# Altium schematic sheet front-end.SchDoc
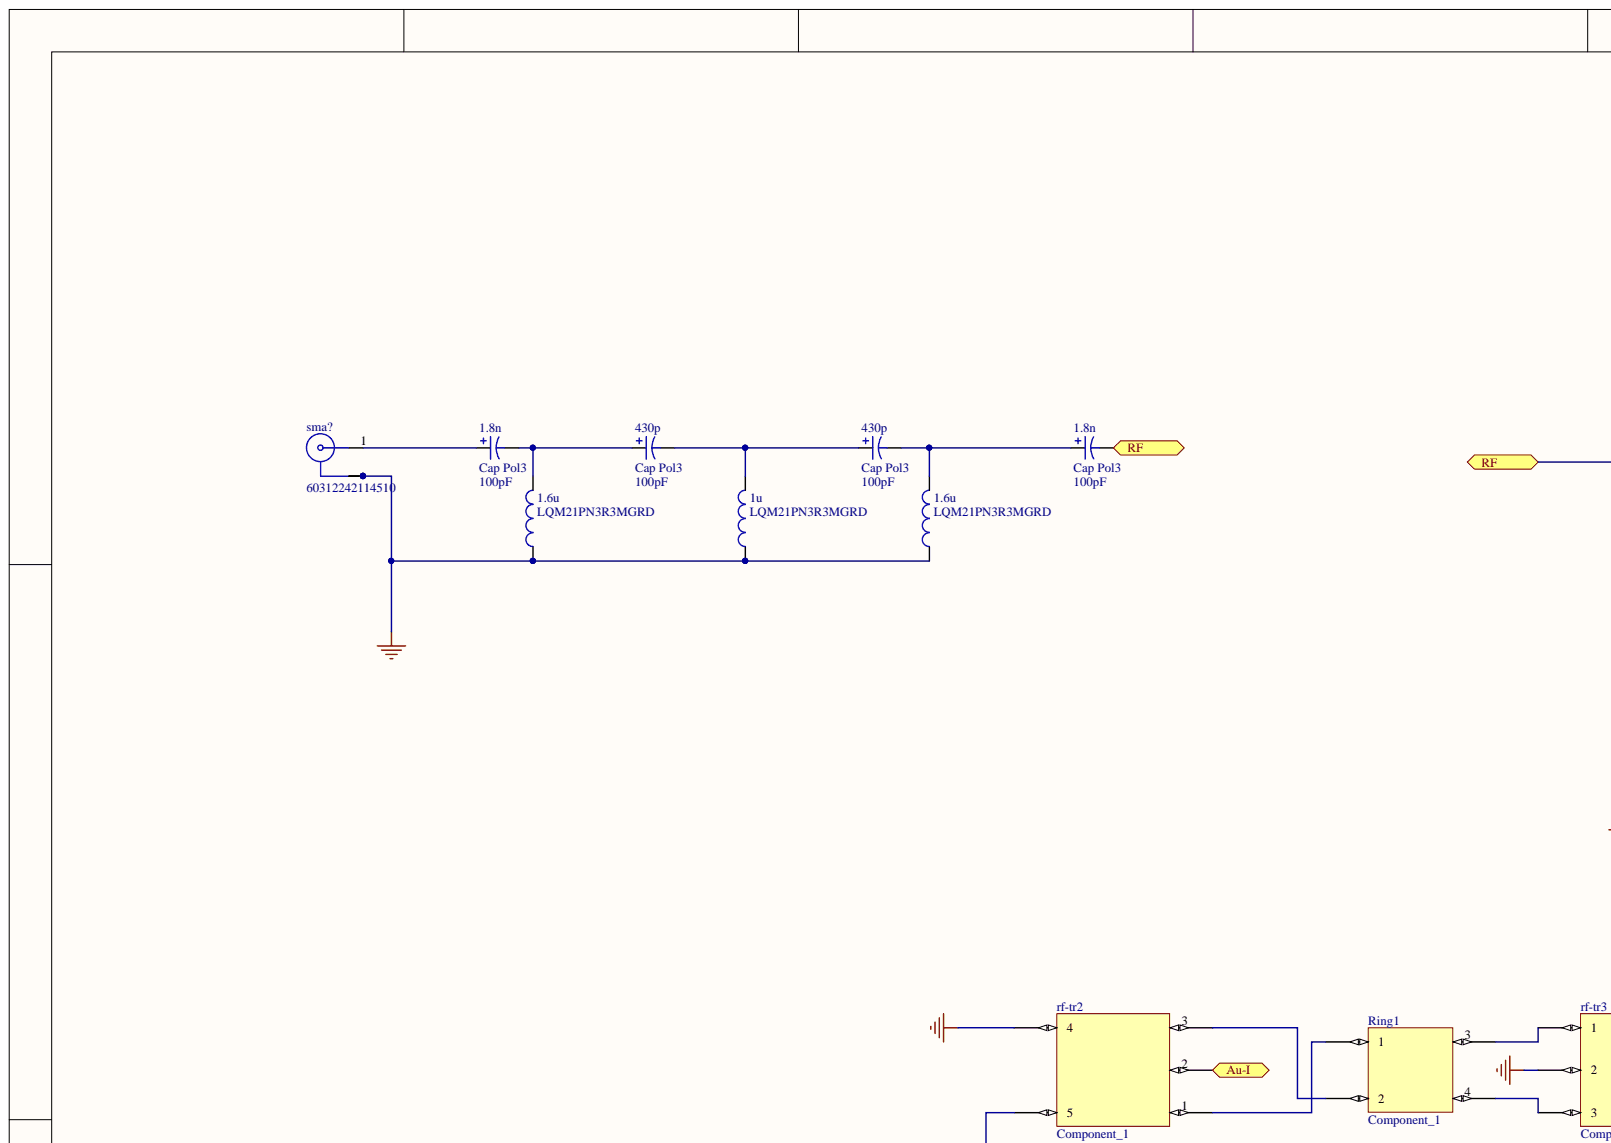
[diagram p0: front-end.SchDoc, top left]
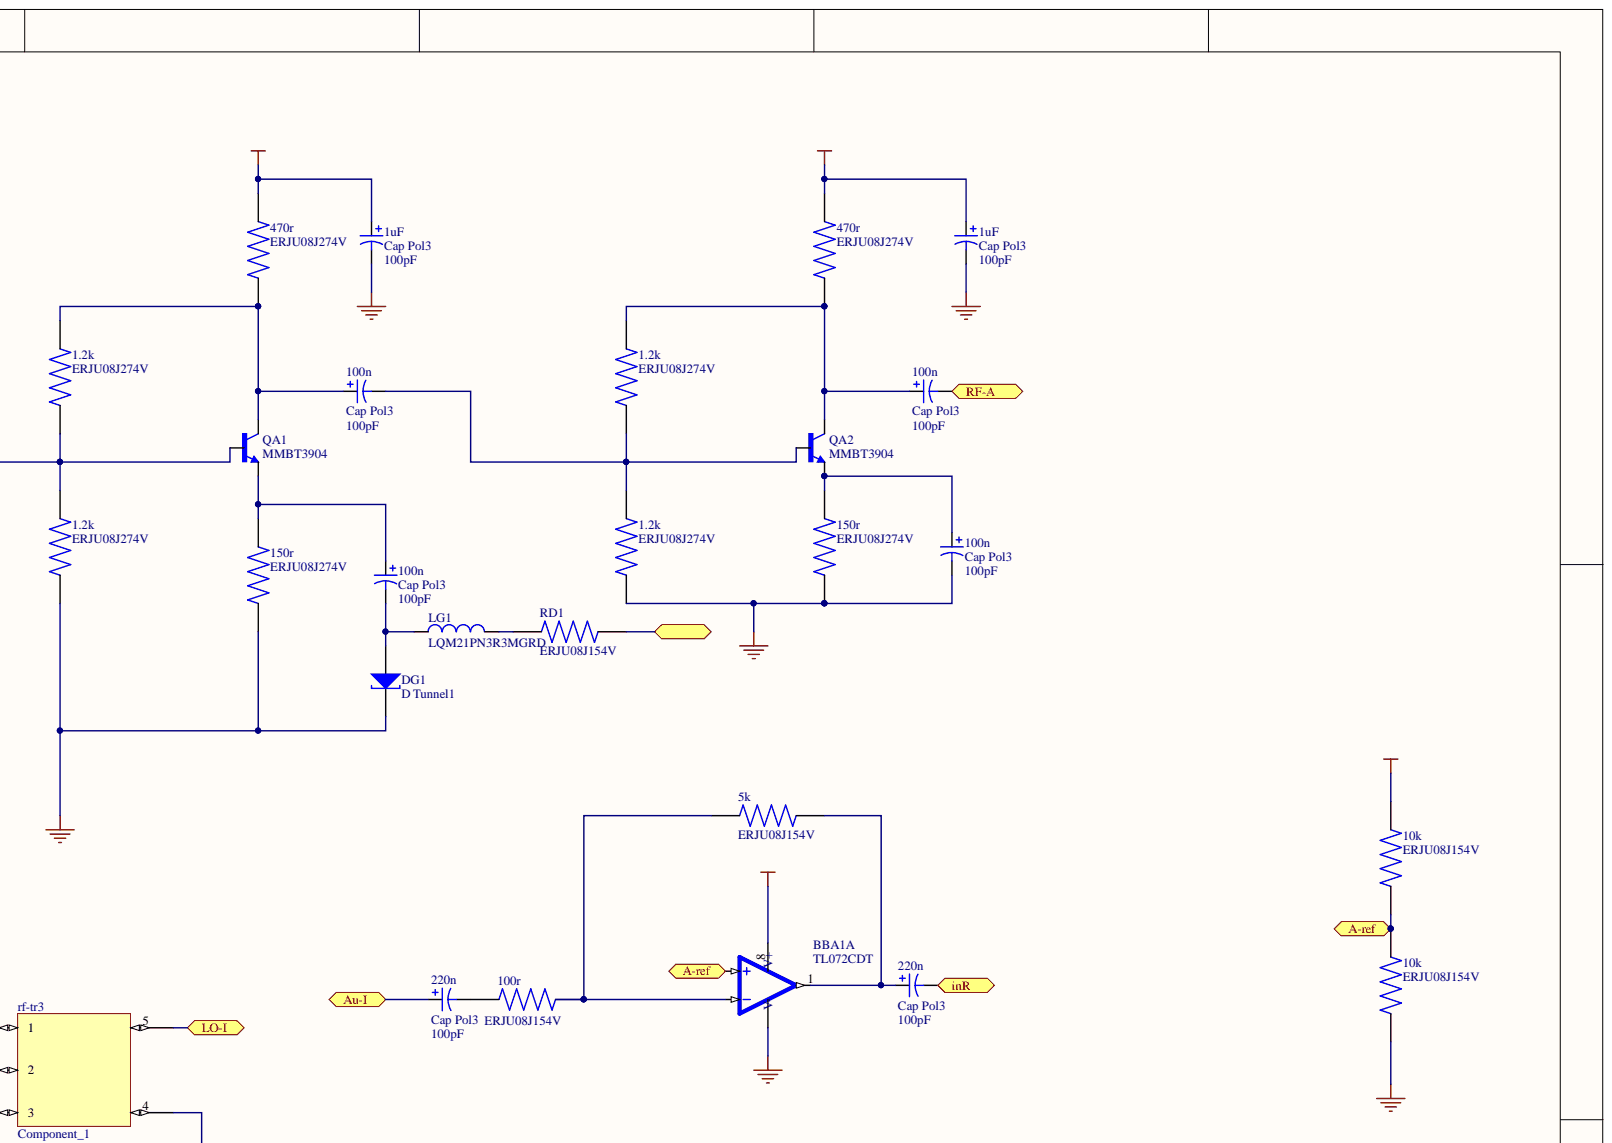
[diagram p1: front-end.SchDoc, top right]
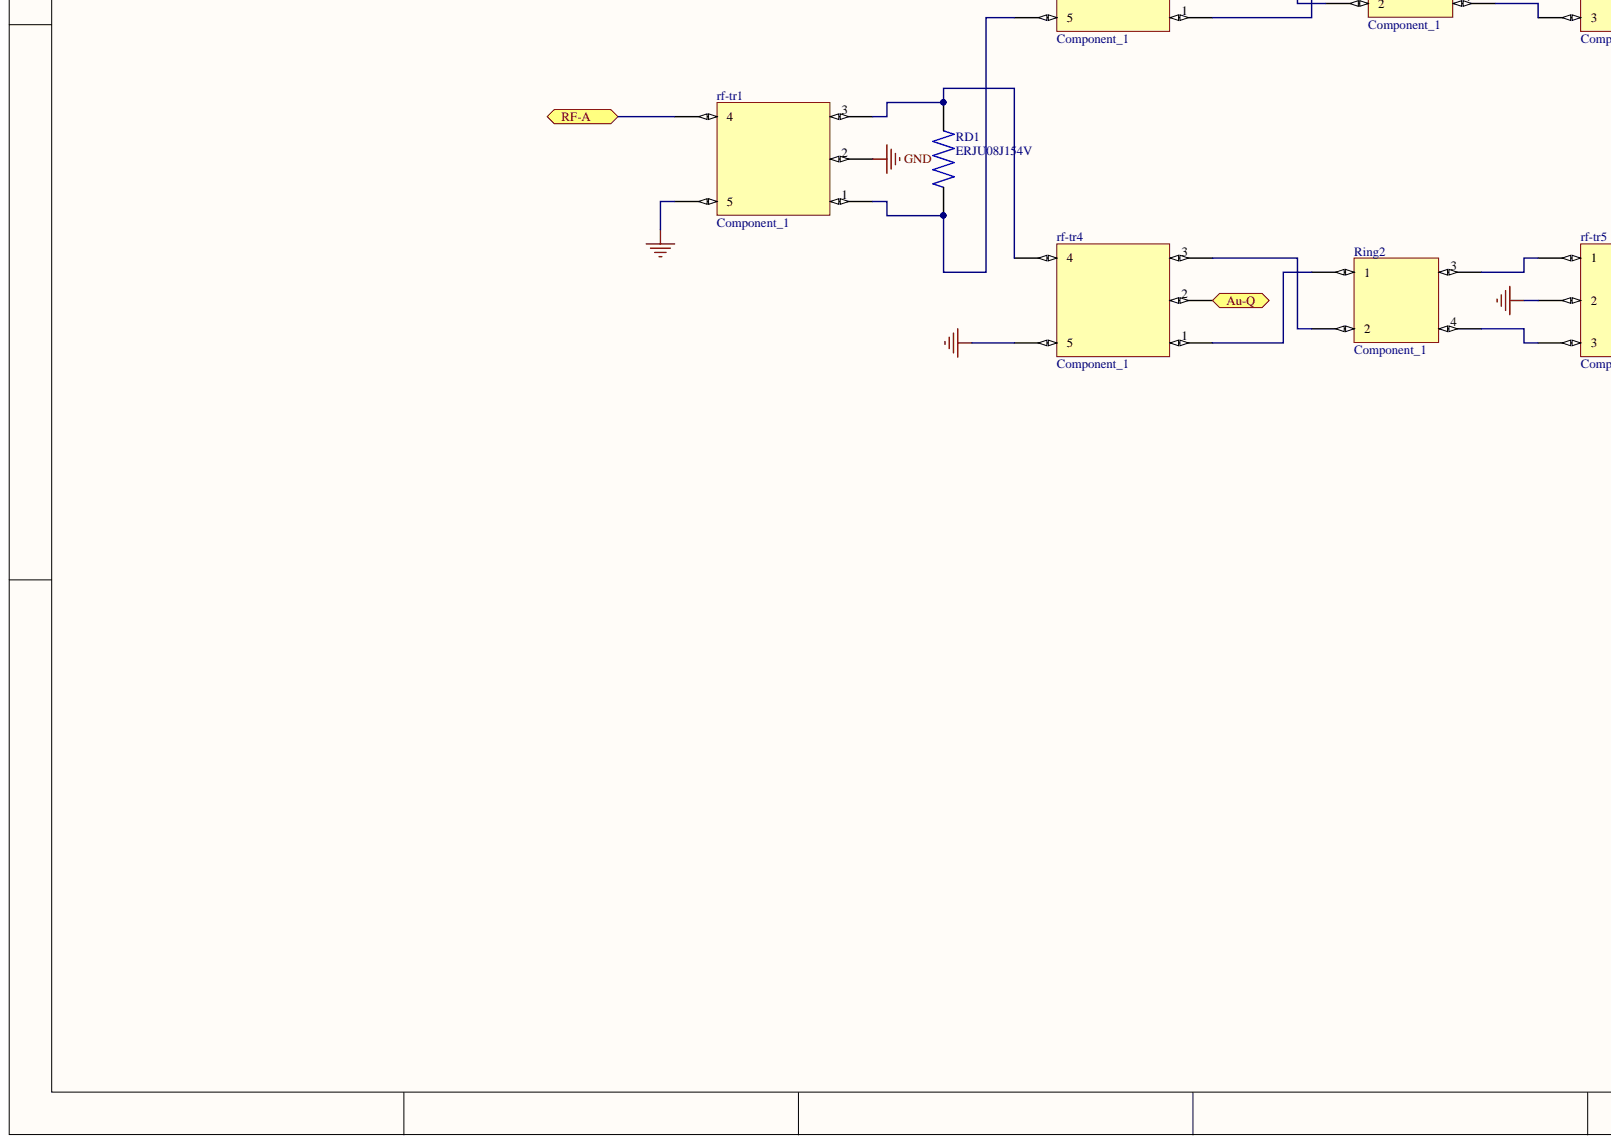
[diagram p2: front-end.SchDoc, bottom left]
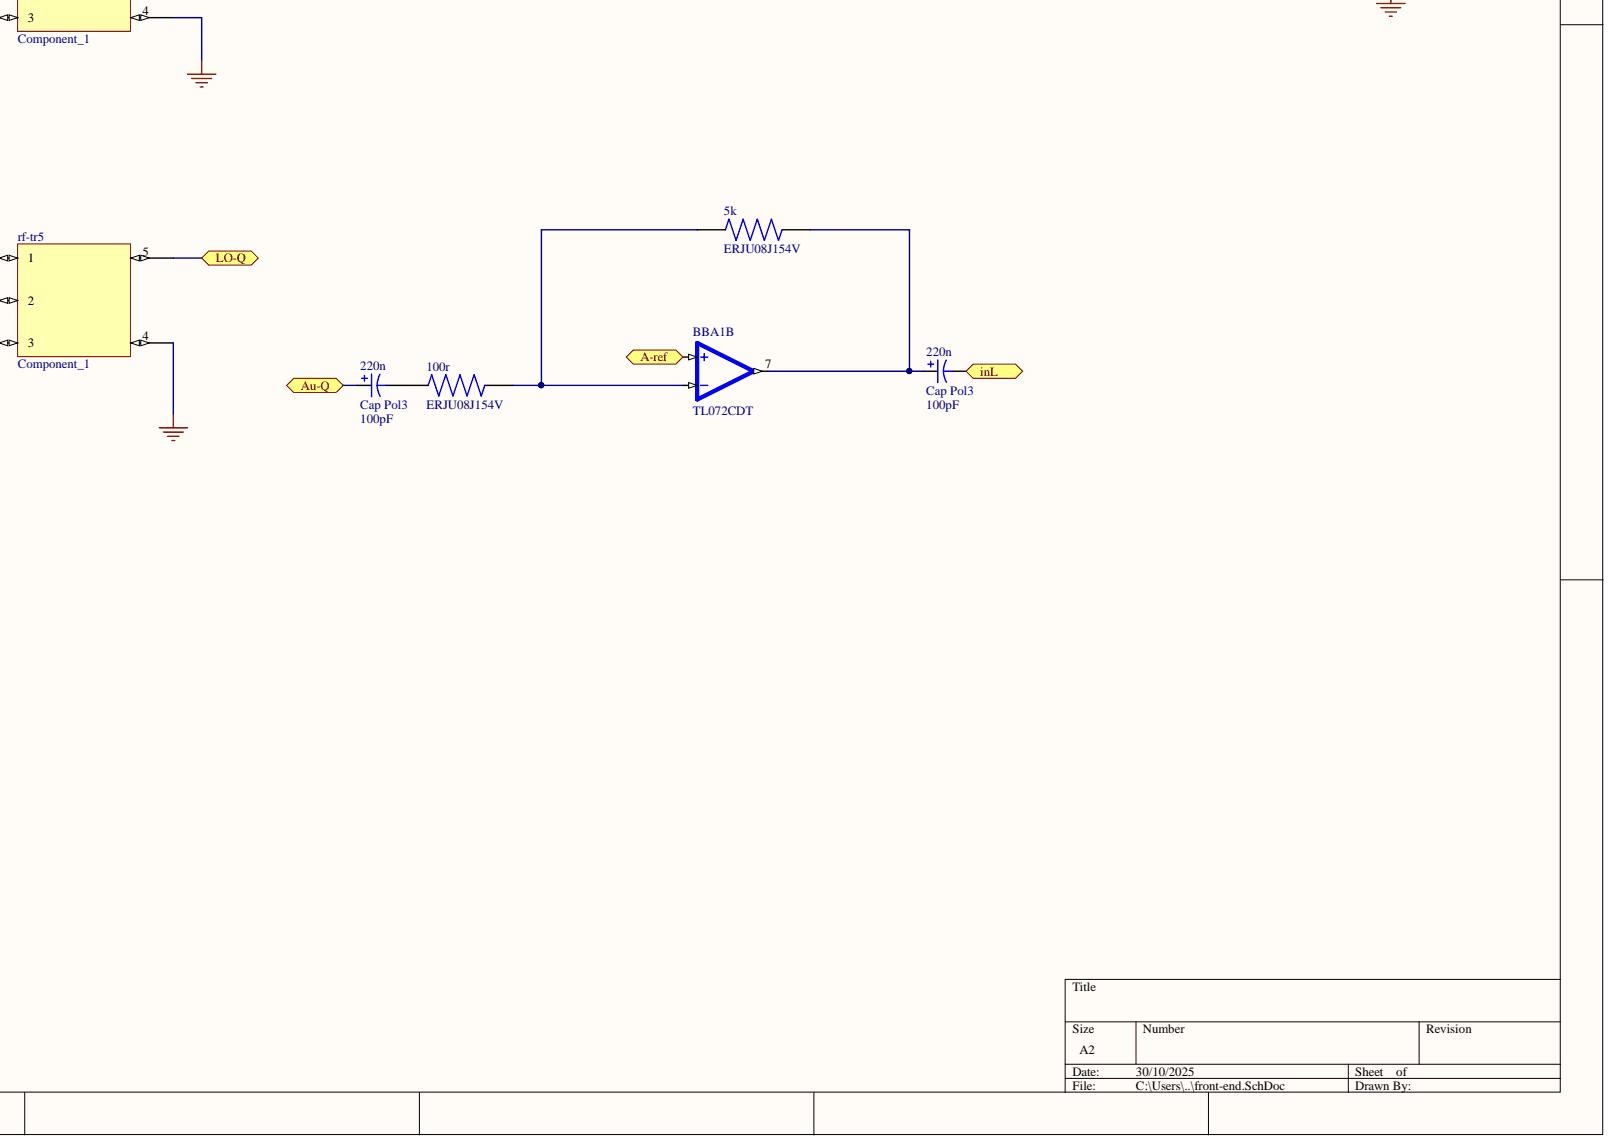
[diagram p3: front-end.SchDoc, bottom right]

## Parts
1.2k  ERJU08J274V  [RES_ERJU08J274V]
1.2k  ERJU08J274V  [RES_ERJU08J274V]
1.2k  ERJU08J274V  [RES_ERJU08J274V]
1.2k  ERJU08J274V  [RES_ERJU08J274V]
1.6u  LQM21PN3R3MGRD  [INDC2012X100N]
1.6u  LQM21PN3R3MGRD  [INDC2012X100N]
1.8n  Cap Pol3  value 100pF  [C0805]
1.8n  Cap Pol3  value 100pF  [C0805]
1u  LQM21PN3R3MGRD  [INDC2012X100N]
1uF  Cap Pol3  value 100pF  [C0805]
1uF  Cap Pol3  value 100pF  [C0805]
5k  ERJU08J154V  [RES_ERJU08J154V]
5k  ERJU08J154V  [RES_ERJU08J154V]
10k  ERJU08J154V  [RES_ERJU08J154V]
10k  ERJU08J154V  [RES_ERJU08J154V]
100n  Cap Pol3  value 100pF  [C0805]
100n  Cap Pol3  value 100pF  [C0805]
100n  Cap Pol3  value 100pF  [C0805]
100n  Cap Pol3  value 100pF  [C0805]
100r  ERJU08J154V  [RES_ERJU08J154V]
100r  ERJU08J154V  [RES_ERJU08J154V]
150r  ERJU08J274V  [RES_ERJU08J274V]
150r  ERJU08J274V  [RES_ERJU08J274V]
220n  Cap Pol3  value 100pF  [C0805]
220n  Cap Pol3  value 100pF  [C0805]
220n  Cap Pol3  value 100pF  [C0805]
220n  Cap Pol3  value 100pF  [C0805]
430p  Cap Pol3  value 100pF  [C0805]
430p  Cap Pol3  value 100pF  [C0805]
470r  ERJU08J274V  [RES_ERJU08J274V]
470r  ERJU08J274V  [RES_ERJU08J274V]
BBA1  TL072CDT  [SOIC127P600X175-8N]  (parts A,B)
DG1  D Tunnel1  [3.2X1.6X1.1]
LG1  LQM21PN3R3MGRD  [INDC2012X100N]
QA1  MMBT3904  [TRANS_MMBT3904]
QA2  MMBT3904  [TRANS_MMBT3904]
RD1  ERJU08J154V  [RES_ERJU08J154V]
RD1  ERJU08J154V  [RES_ERJU08J154V]
rf-tr1  Component_1  [RF-transformer]
rf-tr2  Component_1  [RF-transformer]
rf-tr3  Component_1  [RF-transformer]
rf-tr4  Component_1  [RF-transformer]
rf-tr5  Component_1  [RF-transformer]
Ring1  Component_1  [TRANS_BFP183E7764HTSA1]
Ring2  Component_1  [TRANS_BFP183E7764HTSA1]
sma?  60312242114510  [60312242114510]

## Ports
A-ref, Au-I, Au-Q, inL, inR, LO-I, LO-Q, RF, RF-A, VGA1

## Nets
5V: 1uF.1 10k.2 470r.2 BBA1.8
GND: 1.2k.1 1.6u.1 1u.1 1uF.2 10k.1 100n.2 150r.1 BBA1.4 DG1.2 rf-tr1.2 rf-tr1.5 rf-tr2.4 rf-tr3.2 rf-tr3.4 rf-tr4.5 rf-tr5.2 rf-tr5.4 sma?.2 sma?.3 sma?.4 sma?.5
A-ref: 10k.1 10k.2 BBA1.3 BBA1.5 ; port A-ref
Au-I: 220n.1 rf-tr2.2 rf-tr4.2 ; port Au-I, port Au-Q
inL: 220n.2 ; port inL, port inR
LO-I: rf-tr3.5 ; port LO-I
LO-Q: rf-tr5.5 ; port LO-Q
RF: 1.2k.1 1.2k.2 1.8n.2 100n.2 QA1.1 QA2.1 ; port RF
RF-A: 100n.2 DG1.1 LG1.1 rf-tr1.4 ; port RF-A
VGA1: RD1.2 rf-tr1.3 rf-tr4.4 ; port VGA1
Net1.2k_2: 1.2k.2 100n.1 470r.1 QA1.3 QA2.3
Net1.6u_2: 1.6u.2 1.8n.1 1.8n.2 430p.1 430p.2
Net1.8n_1: 1.8n.1 sma?.1
Net1u_2: 1u.2 430p.1 430p.2
Net5k_1: 5k.1 100r.2 220n.1 BBA1.2(V-) BBA1.7
Net5k_2: 5k.2 100r.1 220n.1 BBA1.1 BBA1.6
Net100n_1: 100n.1 150r.2 QA1.2 QA2.2
Net100r_1: 100r.1 220n.2
Net100r_2: 100r.2 220n.2
NetLG1_2: LG1.2 RD1.1
NetRD1_1: RD1.1 rf-tr1.1 rf-tr2.5
Netrf-tr2_1: rf-tr2.1 Ring1.1
Netrf-tr2_3: rf-tr2.3 Ring1.2
Netrf-tr3_1: rf-tr3.1 Ring1.3
Netrf-tr3_3: rf-tr3.3 Ring1.4
Netrf-tr4_1: rf-tr4.1 Ring2.1
Netrf-tr4_3: rf-tr4.3 Ring2.2
Netrf-tr5_1: rf-tr5.1 Ring2.3
Netrf-tr5_3: rf-tr5.3 Ring2.4

## Library data of the parts
1.6u 1.6u 1u LG1 = LQM21PN3R3MGRD: 0805 Multillayer Type (Ferrite Core) for Power lines; Availability: Unavailable; Component Kind: Standard; Library Name: LQM21PN3R3MGRD.IntLib; MP: LQM21PN3R3MGRD; Package: None; Price: None
1.8n 1.8n 1uF 1uF 100n 100n 100n 100n 220n 220n 220n 220n 430p 430p = Cap Pol3: Polarized Capacitor (Surface Mount); Component Kind: Standard; LatestRevisionDate: 29-May-2009; LatestRevisionNote: IPC-7351 Footprint Added.; Library Name: Miscellaneous Devices.IntLib; PackageDescription: Chip Capacitor; PackageReference: C0805; Published: 8-Jun-2000; Signal Integrity: Cap Pol; Simulation: CAP; Publisher: Altium Limited
5k 5k 10k 10k 100r 100r RD1 RD1 = ERJU08J154V: 1206 anti-sulfurated resistor 1206 Anti-Sulfurated; MF: Panasonic; MP: ERJ-U08J154V; Check_prices: https://www.snapeda.com/parts/ERJ-U08J154V/Panasonic/view-part/?ref=eda; Component Kind: Standard; Library Name: ERJU08J154V.IntLib; Package: 3216-2 Panasonic; SnapEDA_Link: https://www.snapeda.com/parts/ERJ-U08J154V/Panasonic/view-part/?ref=snap
BBA1 = TL072CDT: Dual Low-Noise JFET-Input General-Purpose Operational Amplifier 8-SOIC 0 to 70; MF: STMicroelectronics; Check_prices: https://www.snapeda.com/parts/TL072CD/STMicroelectronics/view-part/?ref=eda; Component Kind: Standard; Library Name: TL072CDT.IntLib; MP: TL072CD; Package: SOIC-8 Texas Instruments; SnapEDA_Link: https://www.snapeda.com/parts/TL072CD/STMicroelectronics/view-part/?ref=snap
DG1 = D Tunnel1: Tunnel Diode - RLC Model; Component Kind: Standard; LatestRevisionDate: 17-Jul-2002; LatestRevisionNote: Re-released for DXP Platform.; Library Name: Miscellaneous Devices.IntLib; PackageDescription: SM LED; 2 Flat Leads; PackageReference: 3.2X1.6X1.1; PackageVersion: Feb-2002; Published: 8-Jun-2000; Signal Integrity: D Tunnel; Simulation: DTUNNEL1; Publisher: Altium Limited
QA1 QA2 = MMBT3904: BJT, SOT-23, 40V, 200mA, NPN, 0.35W, 150Â°C; MF: Diotec Semiconductor; Check_prices: https://www.snapeda.com/parts/MMBT3904/Diotec/view-part/?ref=eda; Component Kind: Standard; Library Name: MMBT3904.IntLib; MP: MMBT3904; Package: SOT-23 Diotec; SnapEDA_Link: https://www.snapeda.com/parts/MMBT3904/Diotec/view-part/?ref=snap
rf-tr1-rf-tr5 = Component_1: RF-transformer; Component Kind: Standard; Library Name: rf-transformer.SchLib
sma? = 60312242114510: RF COAX CONN, SMA JACK, 50 OHM, PCB;; MF: Wurth Electronics; Check_prices: https://www.snapeda.com/parts/60312242114510/Wurth+Electronics+Inc/view-part/?ref=eda; Component Kind: Standard; Library Name: 60312242114510.IntLib; MP: 60312242114510; Package: None; SnapEDA_Link: https://www.snapeda.com/parts/60312242114510/Wurth+Electronics+Inc/view-part/?ref=snap
1.2k 1.2k 1.2k 1.2k 150r 150r 470r 470r = ERJU08J274V: Availability: Unavailable; Component Kind: Standard; Library Name: ERJU08J274V.IntLib; MP: ERJU08J274V; Package: None; Price: None
Ring1 Ring2 = Component_1: Component Kind: Standard; Library Name: Diode-ring.SchLib
